# Altium schematic sheet Power.SchDoc
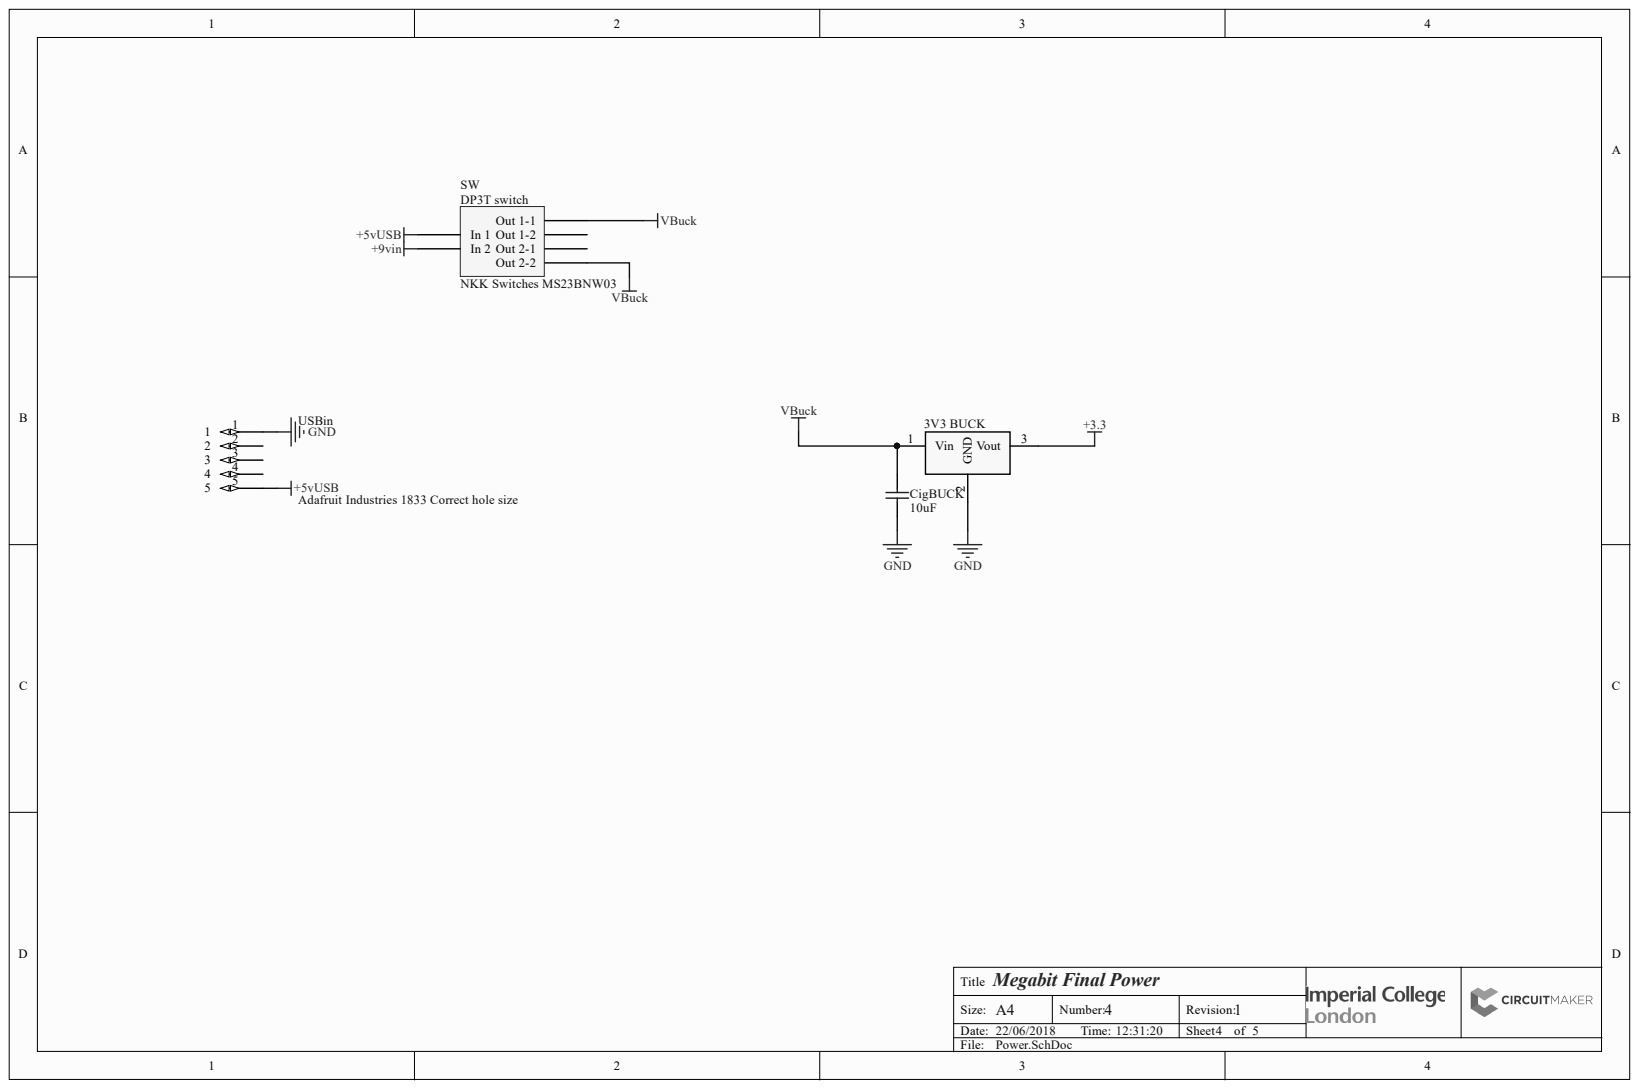
[diagram p0: Power.SchDoc]

## Parts
3V3_BUCK  Tracopower TSR 1-2433  [PCB-vczw4772xz5kvcrv4x78-1]  (value not drawn)
CigBUCK  10uF  [Vishay K104K15X7RF5TL2]
SW  NKK Switches MS23BNW03  [PCB-eozfiwzr1uk89188ni00-1]
USBin  Adafruit Industries 1833 Correct hole size  [PCB-lrx1ysvqy1j2hi3n37c6-1]

## Nets
+3.3: 3V3_BUCK.3
+5VUSB: SW.2(In_1) USBin.5
+9VIN: SW.5(In_2)
GND: 3V3_BUCK.2 CigBUCK.1 USBin.1
VBUCK: 3V3_BUCK.1 CigBUCK.2 SW.1(Out_1-1) SW.6(Out_2-2)

Not connected: SW 3(Out_1-2) 4(Out_2-1); USBin 2 3 4

## Library data of the parts
3V3_BUCK = CMP-395cf0c79334e69b-1: 1 A Watt, 4.75-36VDCVin, Quad Output, 3.3 V@1 A Switching Regulators DC-DC Converter; Input Voltage (DC): 4.75 V to 36.0 V; Number of Outputs: 1; Output Current: 1.00 A; Output Voltage: 3.30 V; Component Kind: Standard; Library Name: CommunityVault; Manufacturer Part Number: TSR 1-2433; Mounting Style: PCB; OctopartID: 395cf0c79334e69b; Octopart URL: http://octopart.com/tsr+1-2433-tracopower-9539342; REACH SVHC Compliance: No SVHC; RoHS: Compliant; Size-Height: 10.2 mm; Size-Width: 7.60 mm
CigBUCK = CMP-124240-16: K Series 100nF 50 V ±10 % Tolerance X7R Radial Multilayer Ceramic Capacitor; Manufacturer Part Number: K104K15X7RF5TL2; Capacitance Tolerance: ±10%; Lead-Free Status: Lead Free; Lead Length: 30mm; Voltage Rating (DC): 50 V; Capacitance: 100 nF; Component Kind: Standard; Dielectric Characteristic: X7R; Dielectric Material: Ceramic; Library Name: CommunityVault; Mounting Style: Through Hole; Number of Pins: 2; OctopartID: e7292e20c87538ee; Octopart URL: http://octopart.com/k104k15x7rf5tl2-vishay+bccomponents-199226; Packaging: Cut Tape (CT), Tape & Reel (TR); RoHS: Compliant; Size-Height: 5.6mm; Size-Lead Diameter: 0.5mm; Size-Thickness: 2.6mm; Size-Width: 4.0mm
SW = CMP-e82c21266ecb37eb-4: dp3t on off on switch; Component Kind: Standard; Library Name: FavoritesLibrary
USBin = CMP-bfb17591a6f36076-2: Standoff; Threaded Female 1/4 Hex; 6-32 Thread; Length .875; Brass/Zinc; Manufacturer Part Number: 1833; Lead-Free Status: Lead Free; Component Kind: Standard; Gender: Female; Library Name: FavoritesLibrary; Material: Brass; OctopartID: bfb17591a6f36076; Octopart URL: http://octopart.com/1833-adafruit+industries-53099556; RoHS: Compliant; Size-Diameter: 6.35 mm; Size-Length: 22.2 mm; Size-Width: 6.35 mm
